# Altium schematic sheet Power Generation - LDO.SchDoc("Power Generation - LDO")
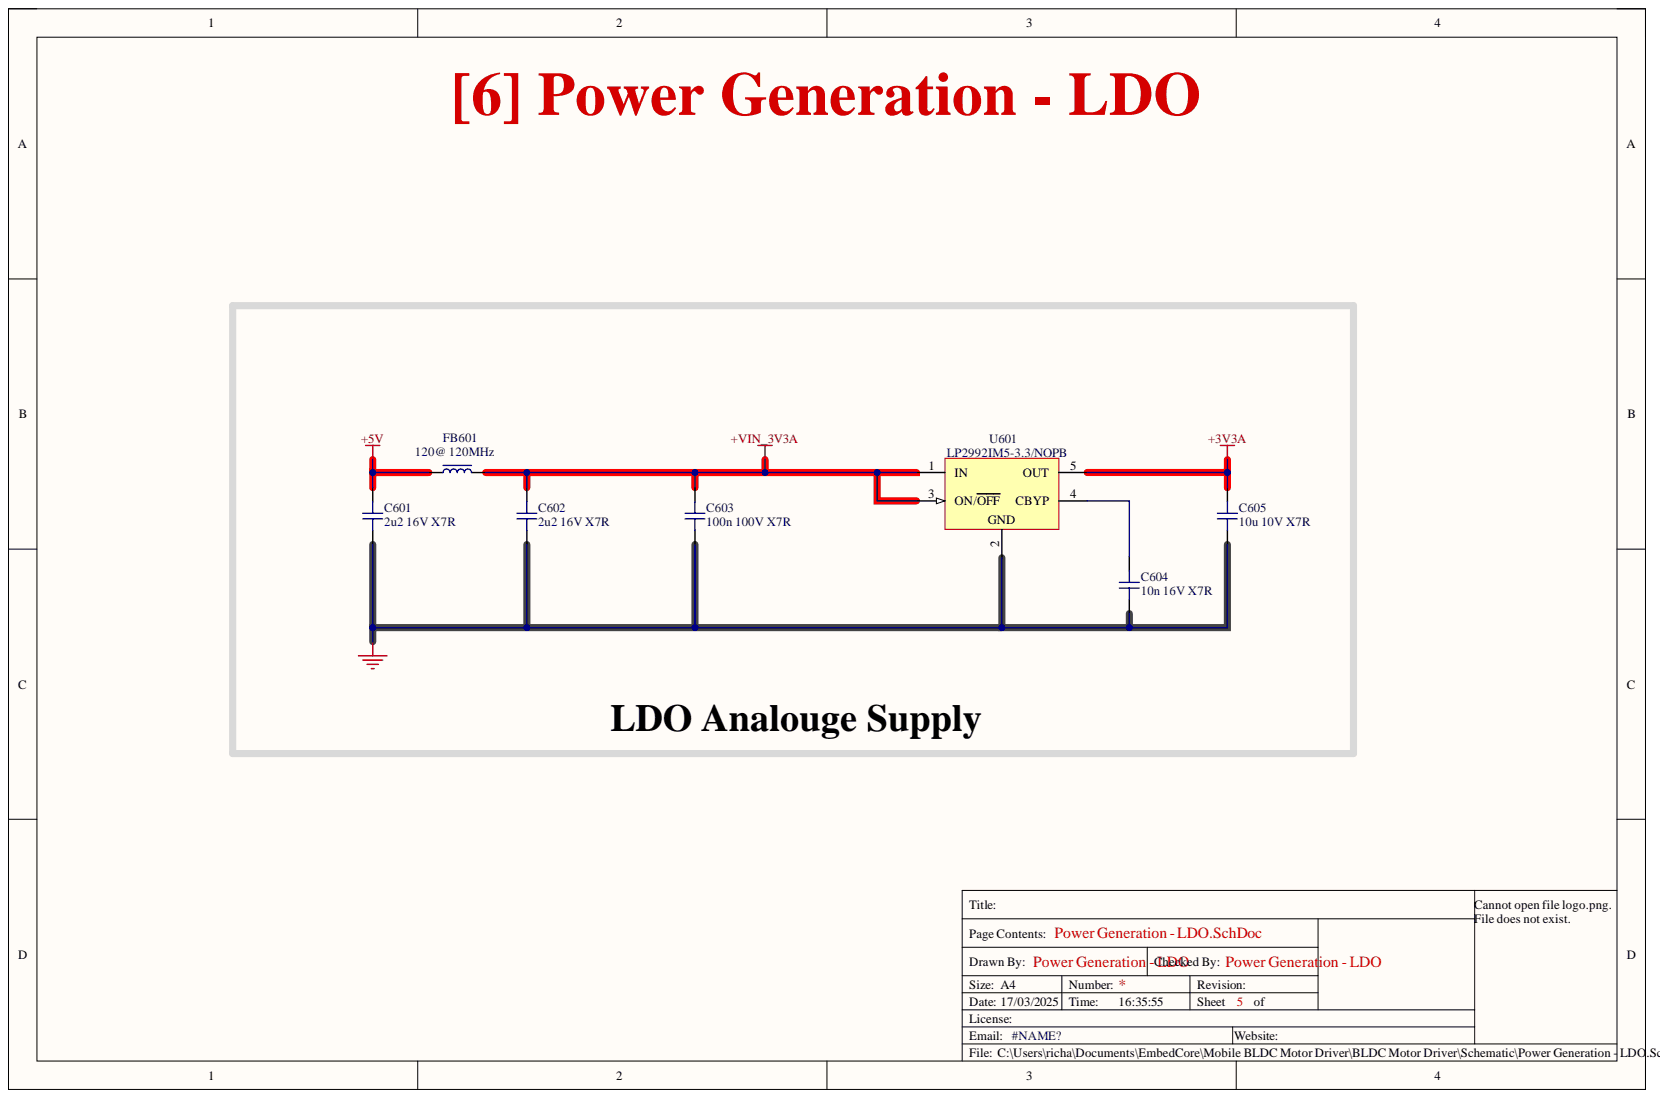
[diagram p0: Power Generation - LDO.SchDoc]

## Parts
C601  2u2 16V X7R  [CAP_0805]
C602  2u2 16V X7R  [CAP_0805]
C603  100n 100V X7R  [CAP_0805]
C604  10n 16V X7R  [CAP_0603]
C605  10u 10V X7R  [CAP_0805]
FB601  120@ 120MHz  [FB_0805]
U601  LP2992IM5-3.3/NOPB  [DBV0005A_L]

## Nets
+3V3A: C605.1 U601.5(OUT)
+5V: C601.1 FB601.1
+VIN_3V3A: C602.1 C603.1 FB601.2 U601.1(IN) U601.3(ON/OFF)
GND: C601.2 C602.2 C603.2 C604.1 C605.2 U601.2
NetC604_2: C604.2 U601.4(CBYP)

## Library data of the parts
C601 C602 = CAP_0805: Capacitor SMD 0805; Manufacturer: HRE; Manufacturer Part Number: CGA0805X7R225K160MT; Component Kind: Standard; Distributor Link: www.lcsc.com; LCSC: C6119936; Library Name: Schematic-Lib-PhilsLab.SchLib
C603 = CAP_0805: Capacitor SMD 0805; Manufacturer: YAGEO; Manufacturer Part Number: CC0805JRX7R7BB104; Component Kind: Standard; Distributor Link: www.lcsc.com; LCSC: CC0805JRX7R7BB104; Library Name: Schematic-Lib-PhilsLab.SchLib
C604 = CAP_0603: Capacitor SMD 0603; Manufacturer: YAGEO; Manufacturer Part Number: CC0603JRX7R7BB103; Component Kind: Standard; Distributor Link: www.lcsc.com; LCSC: C519509; Library Name: Schematic-Lib-PhilsLab.SchLib
C605 = CAP_0805: Capacitor SMD 0805; Manufacturer: Murata Electronics; Manufacturer Part Number: GCM21BR71A106KE22K; Component Kind: Standard; Distributor Link: www.lcsc.com; LCSC: C2167756; Library Name: Schematic-Lib-PhilsLab.SchLib
FB601 = FB_0805: Ferrite Bead SMD 0805; Manufacturer: Murata Electronics; Manufacturer Part Number: BLM21AG121SN1D; Component Kind: Standard; Distributor Link: www.lcsc.com; LCSC: C88990; Library Name: Schematic-Lib-PhilsLab.SchLib
U601 = CMP-0062-02175-3: Micropower 250 mA Low-Noise Ultra Low-Dropout Regulator, 5-pin SOT-23, Pb-Free; Manufacturer: Texas Instruments; CompType: LDO IC; PackageTopMarking: LFEB*XTT; PowerWise: N; Component Kind: Standard; ComponentLink1Description: Datasheet URL; ComponentLink1URL: http://www.ti.com/general/docs/lit/getliterature.tsp?genericPartNumber=LP2992&fileType=pdf; Library Name: Altium Content Vault; PackageDescription: Molded SOT-23, .115 x .063 x .040 in Body, 5 Lead, .0375 in Pitch; PackageReference: DBV0005A; PinCount: 5; RoHS: Y
